# Altium schematic sheet POWER.SchDoc(POWER)
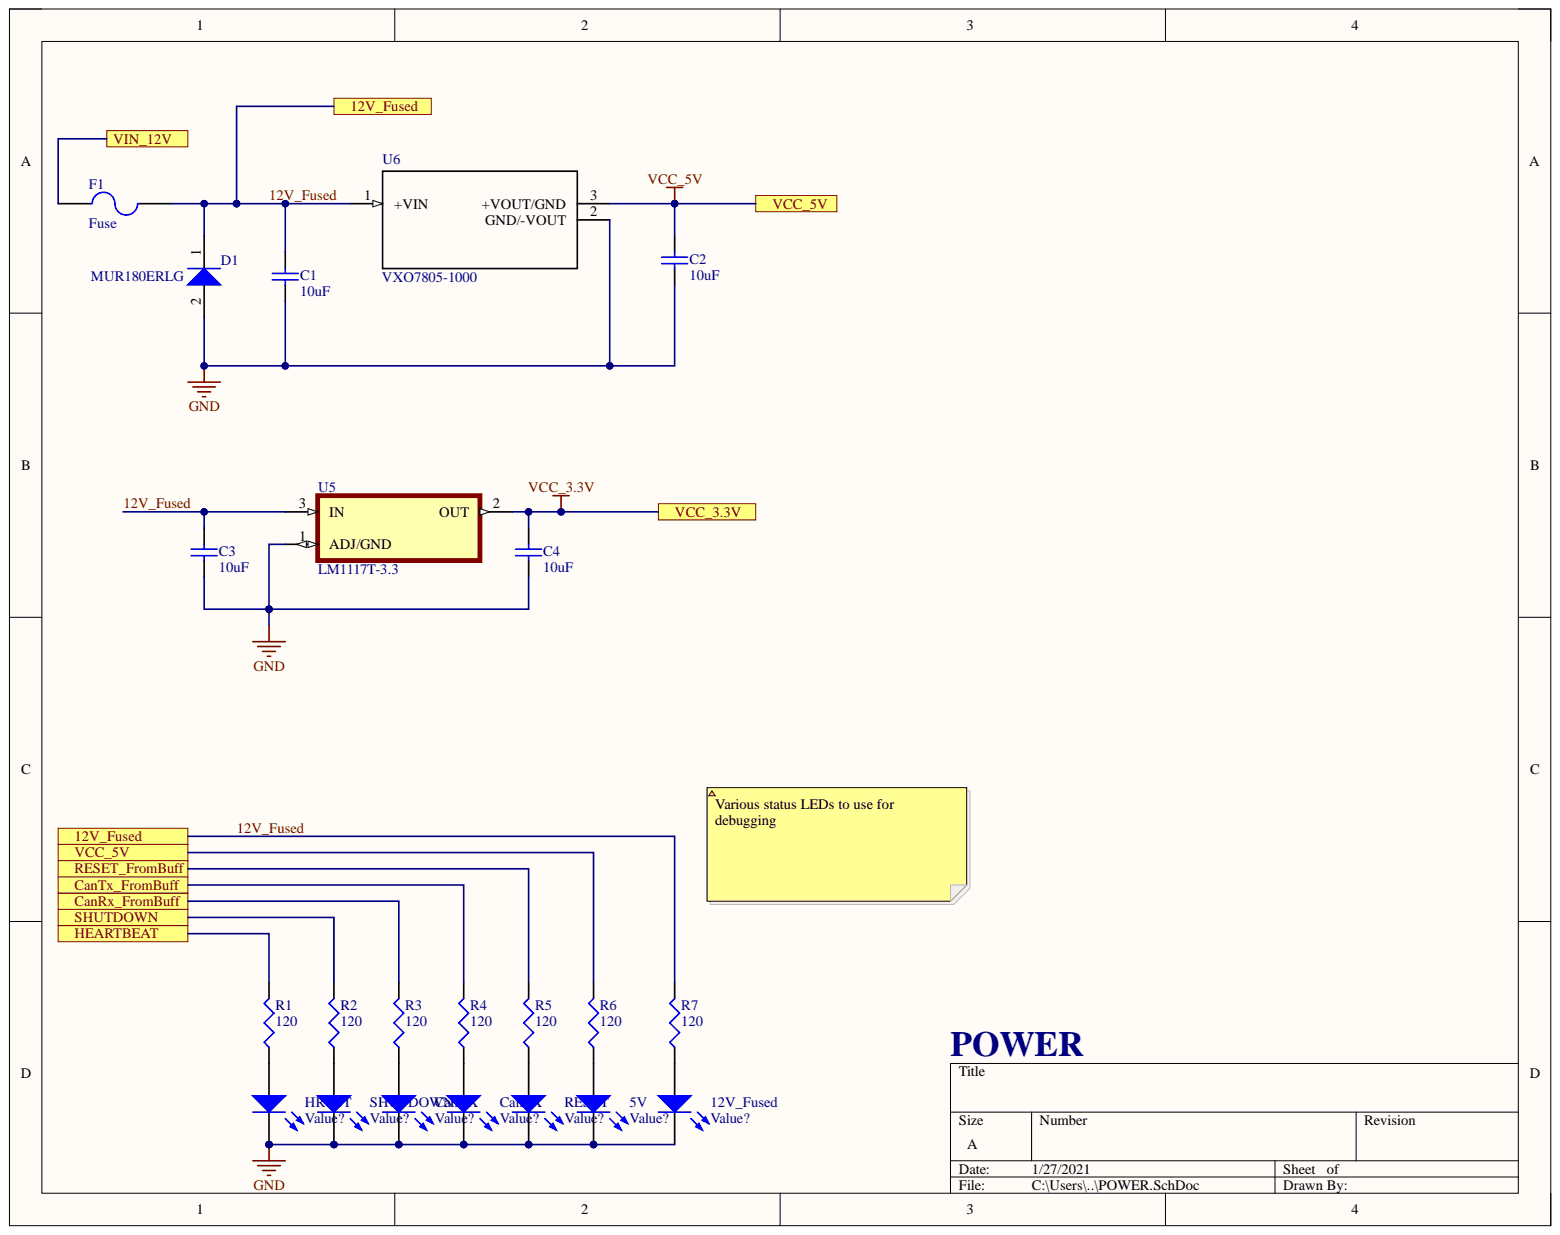
[diagram p0: POWER.SchDoc]

## Parts
5V  Value?  [LED, TH]
12V_Fused  Value?  [LED, TH]
C1  10uF  [Cap, 5mm TH, Ceramic]
C2  10uF  [Cap, 5mm TH, Ceramic]
C3  10uF  [Cap, 5mm TH, Ceramic]
C4  10uF  [Cap, 5mm TH, Ceramic]
CanRX  Value?  [LED, TH]
CanTX  Value?  [LED, TH]
D1  MUR180ERLG  [ONSC-AXIAL_LEAD-2-59-10_P]
F1  Fuse  [PIN-W2/E2.8]
HRTBT  Value?  [LED, TH]
R1  120  [Resistor, TH]
R2  120  [Resistor, TH]
R3  120  [Resistor, TH]
R4  120  [Resistor, TH]
R5  120  [Resistor, TH]
R6  120  [Resistor, TH]
R7  120  [Resistor, TH]
RESET  Value?  [LED, TH]
SHUTDOWN  Value?  [LED, TH]
U5  LM1117T-3.3  [TO254P1054X470X1955-3]
U6  VXO7805-1000  [CONV_VXO7805-1000]

## Ports
12V_Fused, CanRx_FromBuff, CanTx_FromBuff, HEARTBEAT, RESET_FromBuff, SHUTDOWN, VCC_3.3V, VCC_5V, VIN_12V

## Nets
GND: 5V.2 12V_Fused.2 C1.1 C2.1 C3.1 C4.1 CanRX.2 CanTX.2 D1.2 HRTBT.2 RESET.2 SHUTDOWN.2 U5.1 U6.2
12V_Fused: C1.2 C3.2 D1.1 F1.2 R7.2 U5.3 U6.1 ; port 12V_Fused
CanRx_FromBuff: R3.2 ; port CanRx_FromBuff
CanTx_FromBuff: R4.2 ; port CanTx_FromBuff
HEARTBEAT: R1.2 ; port HEARTBEAT
RESET_FromBuff: R5.2 ; port RESET_FromBuff
SHUTDOWN: R2.2 ; port SHUTDOWN
VCC_3.3V: C4.2 U5.2 ; port VCC_3.3V
VCC_5V: C2.2 R6.2 U6.3 ; port VCC_5V
VIN_12V: F1.1 ; port VIN_12V
Net5V_1: 5V.1 R6.1
Net12V_Fused_1: 12V_Fused.1 R7.1
NetCanRX_1: CanRX.1 R3.1
NetCanTX_1: CanTX.1 R4.1
NetHRTBT_1: HRTBT.1 R1.1
NetR2_1: R2.1 SHUTDOWN.1
NetR5_1: R5.1 RESET.1

## Library data of the parts
5V 12V_Fused CanRX CanTX HRTBT RESET SHUTDOWN = LED, TH: LED, 5mm, TH; TargetLibrary: RITRacing-LED's; Component Kind: Standard; Library Name: LED_s.IntLib; Published: 22Oct2016; Status: Active; Value: Value?; Publisher: T.Tallo
C1-C4 = Cap, 5MM TH, Ceramic: Generic Cap, 5MM TH, Ceramic; TargetLibrary: RITRacing-Passives; Value: Value?; Component Kind: Standard; Library Name: Passives.IntLib; Published: 22Oct2016; Status: Active; Publisher: T.Tallo
D1 = CMP-0902-00111-1: SWITCHMODE(TM) Power Rectifier, Ultrafast 'E' Series with High Reverse Energy Capability, 2-Pin Axial_Lead, Pb-Free, Tape and Reel; Manufacturer: On Semiconductor; IFSM Max (A): 35; Component Kind: Standard; ComponentLink1Description: Manufacturer URL; ComponentLink1URL: http://www.onsemi.com/; ComponentLink2Description: Datasheet; ComponentLink2URL: http://www.onsemi.cn/pub_link/Collateral/MUR180E-D.PDF; ComponentLink3Description: Package Specification; ComponentLink3URL: http://www.onsemi.cn/pub_link/Collateral/59-10.PDF; DatasheetVersion: Rev. 4; Library Name: Altium Content Vault; Mounting Technology: Through Hole; PackageDescription: 2-Pin, Axial Lead, Diameter 2.35 mm; PackageReference: AXIAL_LEAD-2-59-10; PackageVersion: Rev. U, 02/2005; Packing: Tape and Reel; PartNumber: MUR180ERLG; RoHS: TRUE; Type: Ultrafast
F1 = Fuse 2: Component Kind: Standard; Description: Fuse; LatestRevisionDate: 17-Jul-2002; LatestRevisionNote: Re-released for DXP Platform.; Library Name: Miscellaneous Devices.IntLib; PackageDescription: Thru-Hole; 2 Leads; PackageReference: PIN-W2/E2.8; Published: 8-Jun-2000; Simulation: FUSE; Publisher: Altium Limited
R1-R7 = Resistor, TH: Generic TH Resistor; TargetLibrary: RITRacing-Passives; Value: Value?; Component Kind: Standard; Library Name: Passives.IntLib; Published: 22Oct2016; Status: Active; Publisher: T.Tallo
U5 = LM1117T-3.3: 800-mA 15-V linear voltage regulator 3-TO-220 0 to 125; MF: Texas Instruments; MP: LM1117T-3.3/NOPB; Arrow_Electronics-Purchase-URL: https://snapeda.com/shop?store=Arrow+Electronics&id=22275; Availability: https://www.mouser.com/ProductDetail/Texas-Instruments/LM1117T-33-NOPB?qs=X1J7HmVL2ZF7RIQB6O0mNg%3D%3D&gclid=Cj0KCQjwvvj5BRDkARIsAGD9vlJnD1MNOYBTPv7FHDAskkwDQ_PuLBSV-edvQAne3qvGjGEuZX3qJSwaAtIgEALw_wcB; Component Kind: Standard; DigiKey-Purchase-URL: https://snapeda.com/shop?store=DigiKey&id=22275; Library Name: LM1117T-3.3.IntLib; Mouser-Purchase-URL: https://snapeda.com/shop?store=Mouser&id=22275; Package: TO-220-3 Texas Instruments; Price: None; SnapEDA_Link: https://www.snapeda.com/parts/LM1117T-3.3/NOPB/Texas%20Instruments/view-part/22275/?ref=snap; Texas_Instruments-Purchase-URL: https://snapeda.com/shop?store=Texas+Instruments&id=22275
U6 = VXO7805-1000: Component Kind: Standard; Library Name: Embedded.IntLib
